# Altium schematic sheet Power.SchDoc(Power)
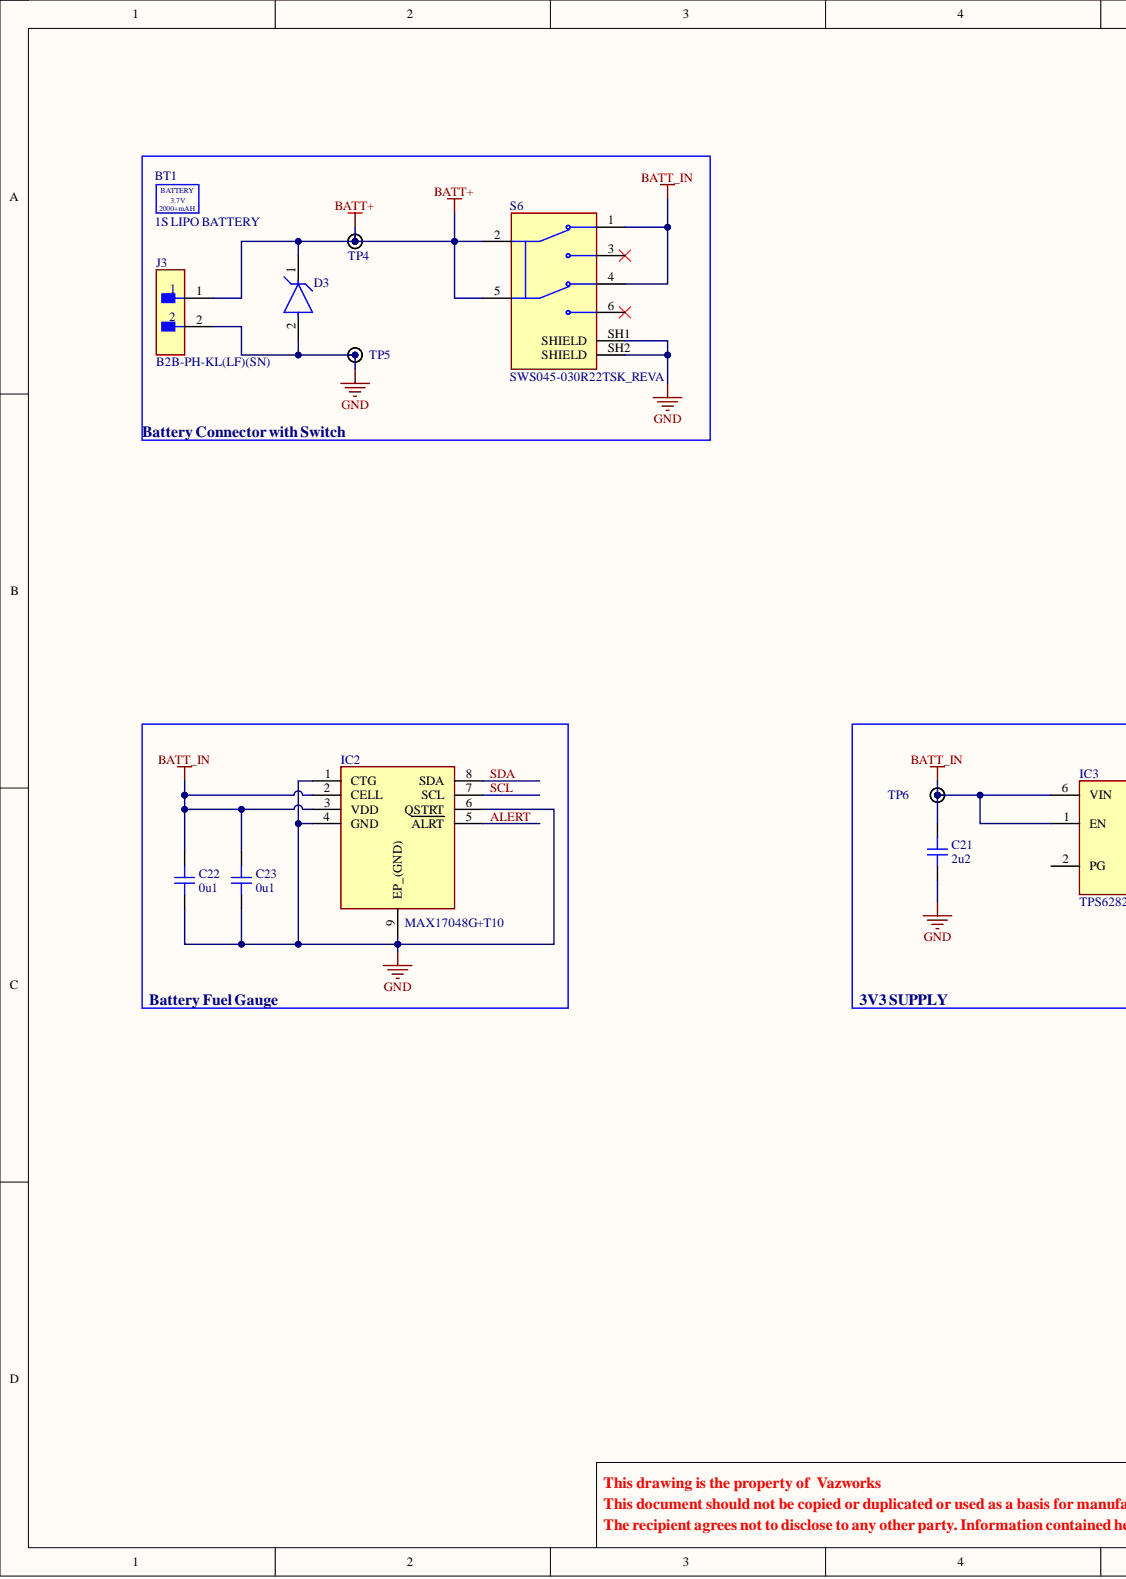
[diagram p0: Power.SchDoc, left]
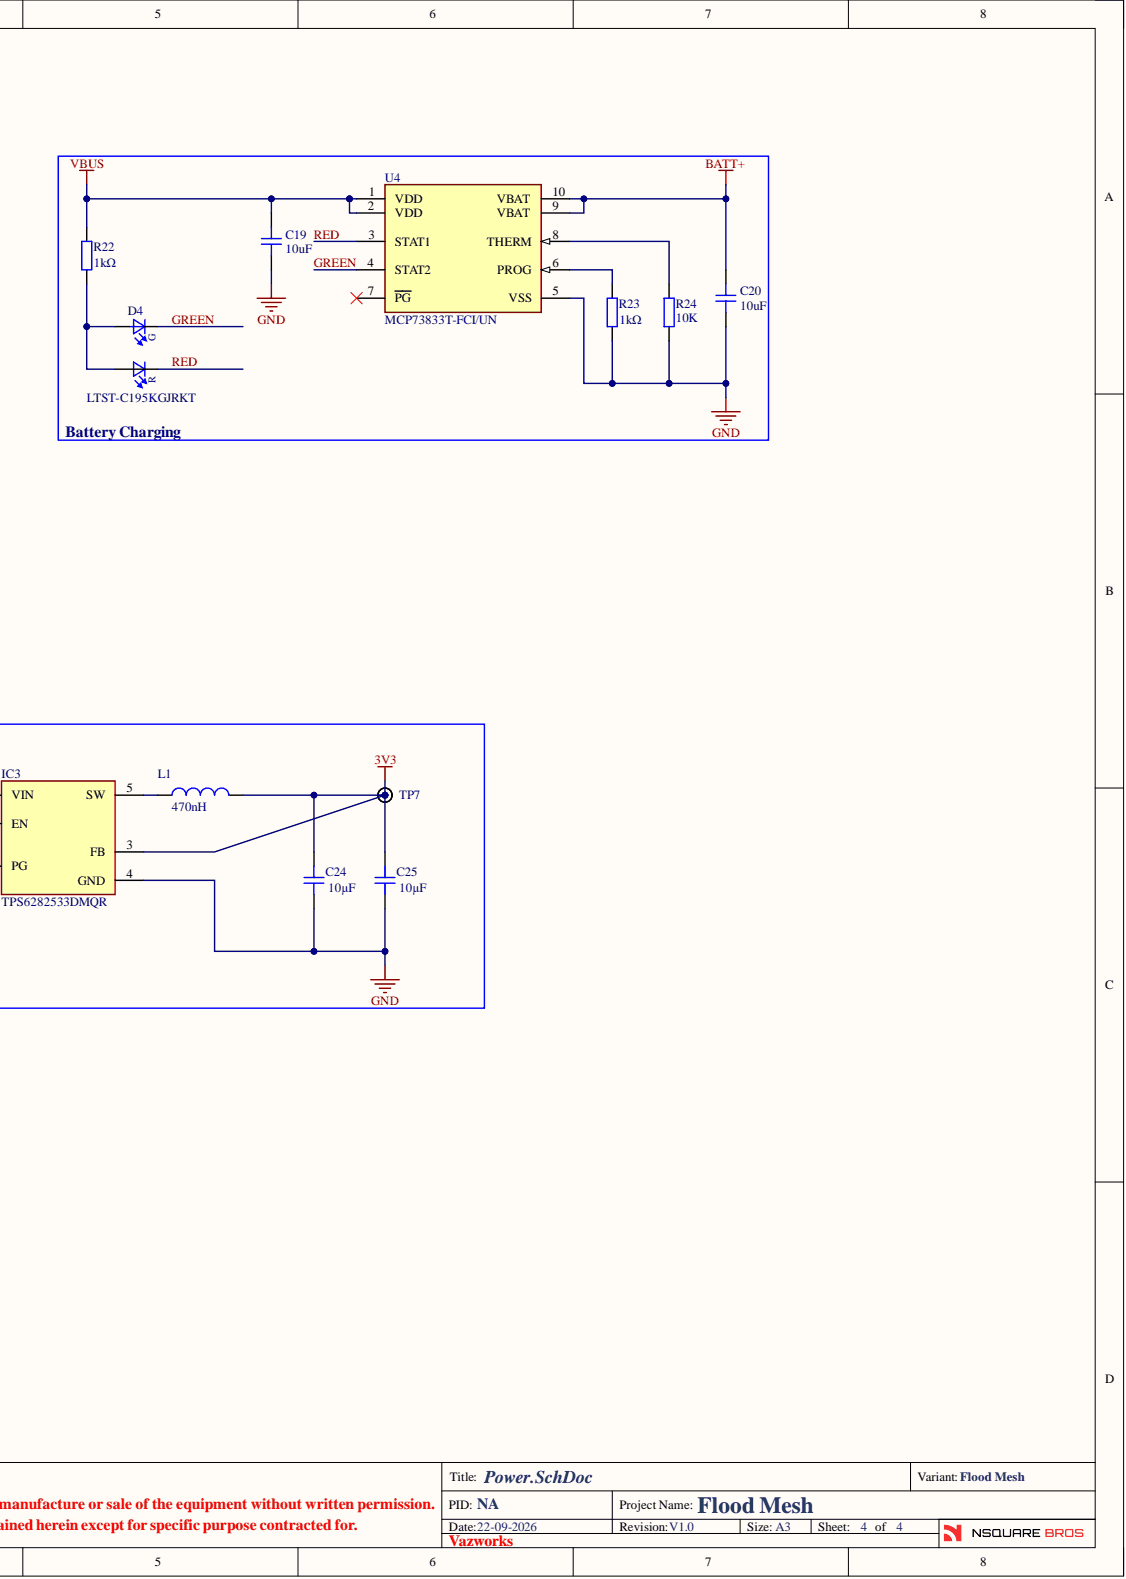
[diagram p1: Power.SchDoc, right]

## Parts
BT1  1S LIPO BATTERY  (mechanical)
C19  value 10uF  [CAP_0603]  (hidden comment: GRM188R60J106ME47D)
C20  value 10uF  [CAP_0603]  (hidden comment: GRM188R60J106ME47D)
C21  value 2u2  [CAP_0603]  (hidden comment: GRM188Z71C225KE43J)
C22  value 0u1  [CAP_0402]  (hidden comment: CC0402JRX7R8BB104)
C23  value 0u1  [CAP_0402]  (hidden comment: CC0402JRX7R8BB104)
C24  value 10µF  [CAP_0603]  (hidden comment: CL10A106KP8NNNC)
C25  value 10µF  [CAP_0603]  (hidden comment: CL10A106KP8NNNC)
D3  ESDA7P60-1U1M  [FP-1610-MFG]  (value not drawn)
D4  LTST-C195KGJRKT  [LTST-BILED-15X16]
IC2  MAX17048G+T10  [SON50P200X200X80-9N-D]
IC3  TPS6282533DMQR  [TPS6282533DMQR]
J3  B2B-PH-KL(LF)(SN)  [FP-B2B-PH-KL_LF_SN-MFG]
L1  Inductance: 470nH  [WE-PMCI_252012]  (hidden comment: 74479288147)
R22  value 1kΩ  [RES_0603]  (hidden comment: AC0603FR-7W1KL)
R23  value 1kΩ  [RES_0603]  (hidden comment: AC0603FR-7W1KL)
R24  value 10K  [RES_0402]  (hidden comment: AC0402FR-7W10KL)
S6  SWS045-030R22TSK_REVA  [SW_SWS045-030R22TSK_REVA]
TP4  TP_NOBOM  [PAD_RND_1MM]  (value not drawn)  (no BOM)
TP5  TP_NOBOM  [PAD_RND_1MM]  (value not drawn)  (no BOM)
TP6  TP_NOBOM  [PAD_RND_1MM]  (value not drawn)  (no BOM)
TP7  TP_NOBOM  [PAD_RND_1MM]  (value not drawn)  (no BOM)
U4  MCP73833T-FCI/UN  [FP-C04-021-IPC_B]

## Nets
3V3: C24.2 C25.2 IC3.3(FB) L1.2 TP7.1
BATT+: C20.2 D3.1 J3.1 S6.2 S6.5 TP4.1 U4.9(VBAT) U4.10(VBAT)
BATT_IN: C21.2 C22.2 C23.2 IC2.2(CELL) IC2.3(VDD) IC3.1(EN) IC3.6(VIN) S6.1 S6.4 TP6.1
GND: C19.1 C20.1 C21.1 C22.1 C23.1 C24.1 C25.1 D3.2 IC2.1(CTG) IC2.4(GND) IC2.6(QSTRT) IC2.9(EP_(GND)) IC3.4(GND) J3.2 R23.1 R24.1 S6.SH1(SHIELD) S6.SH2(SHIELD) TP5.1 U4.5(VSS)
VBUS: C19.2 R22.2 U4.1(VDD) U4.2(VDD)
ALERT: IC2.5(ALRT)
GREEN: D4.P$3 U4.4(STAT2)
RED: D4.P$4 U4.3(STAT1)
SCL: IC2.7(SCL)
SDA: IC2.8(SDA)
NetD4_P$1: D4.P$1 D4.P$2 R22.1
NetIC3_5: IC3.5(SW) L1.1
NetR23_2: R23.2 U4.6(PROG)
NetR24_2: R24.2 U4.8(THERM)

Not connected: IC3 2(PG); S6 3 6; U4 7(PG)

## Library data of the parts
BT1 = Battery: Library Name: Battery.SchLib
C19 C20 = CAP_0603: Ceramic Capacitor, Multilayer, Ceramic, 6.3V, 20% +Tol, 20% -Tol, X5R, 15% TC, 10uF, Surface Mount, 0603; Multilayer: Yes; Capacitor Type: Ceramic; Case/Package: 0603; Category: Ceramic Capacitors; Component Kind: Standard; ComponentLink1Description: https://datasheet.ciiva.com:443/pdfs/VipMasterIC/IC/MURE/MURE-S-A0008911298/MURE-S-A0008911290-1.pdf?src-supplier=IHS+Markit; ComponentLink1URL: https://datasheet.ciiva.com:443/pdfs/VipMasterIC/IC/MURE/MURE-S-A0008911298/MURE-S-A0008911290-1.pdf?src-supplier=IHS+Markit; ComponentLink2Description: https://datasheet.ciiva.com/28584/415295-28584232.pdf?src-supplier=Element14; ComponentLink2URL: https://datasheet.ciiva.com/28584/415295-28584232.pdf?src-supplier=Element14; ComponentLink3Description: https://datasheet.ciiva.com/3777/10175grm188r60j106me4723-3777268.pdf?src-supplier=Arrow+Electronics; ComponentLink3URL: https://datasheet.ciiva.com/3777/10175grm188r60j106me4723-3777268.pdf?src-supplier=Arrow+Electronics; ComponentLink4Description: https://datasheet.ciiva.com/22776/1747457-22776418.pdf?src-supplier=Farnell; ComponentLink4URL: https://datasheet.ciiva.com/22776/1747457-22776418.pdf?src-supplier=Farnell; ComponentLink5Description: https://datasheet.ciiva.com/29202/450781-da-01-de-vs-chip-kond-0603-10-0-uf-20pr-6-3v-x5r-29202806.pdf?src-supplier=Conrad; ComponentLink5URL: https://datasheet.ciiva.com/29202/450781-da-01-de-vs-chip-kond-0603-10-0-uf-20pr-6-3v-x5r-29202806.pdf?src-supplier=Conrad; ComponentLink6Description: https://datasheet.ciiva.com/29199/454401-da-01-en-vs-kondensator-0603-29199677.pdf?src-supplier=Conrad; ComponentLink6URL: https://datasheet.ciiva.com/29199/454401-da-01-en-vs-kondensator-0603-29199677.pdf?src-supplier=Conrad; ComponentLink7Description: https://datasheet.ciiva.com/138/partnumbering-e-01-138659.pdf?src-supplier=Digikey; ComponentLink7URL: https://datasheet.ciiva.com/138/partnumbering-e-01-138659.pdf?src-supplier=Digikey; ComponentLink8Description: https://datasheet.ciiva.com/138/partnumbering-e-01-138658.pdf?src-supplier=Digikey; ComponentLink8URL: https://datasheet.ciiva.com/138/partnumbering-e-01-138658.pdf?src-supplier=Digikey; ComponentLink9Description: https://datasheet.ciiva.com/16373/l0005e-16373580.pdf?src-supplier=Future+Electronics; ComponentLink9URL: https://datasheet.ciiva.com/16373/l0005e-16373580.pdf?src-supplier=Future+Electronics; ComponentLink10Description: https://datasheet.ciiva.com/14116/grmseries-highdielectrictype-eng-14116428.pdf?src-supplier=Distrelec; ComponentLink10URL: https://datasheet.ciiva.com/14116/grmseries-highdielectrictype-eng-14116428.pdf?src-supplier=Distrelec; ComponentLink11Description: https://datasheet.ciiva.com/138/partnumbering-e-01-138660.pdf?src-supplier=Digikey; ComponentLink11URL: https://datasheet.ciiva.com/138/partnumbering-e-01-138660.pdf?src-supplier=Digikey; Dielectric Material: Ceramic; Height: 0.8mm; JESD-609 Code: e3; Length: 1.6mm; Max Operating Temperature: 85°C; Min Operating Temperature: -55°C; Mounting Technology: Surface Mount; Package Shape: Rectangular; Package Style: SMTMeter; Packaging: Tape and Reel; Pins: 2; Pricing: 1=0.14, 10=0.067, 50=0.067, 100=0.041, 1000=0.03, 10000=0.023 (USD); Rated DC Voltage (URdc): 6.3V; Reach SVHC Compliant: Yes; RoHS: Yes; RoHS Compliant: Yes; Stock: 161800; Supplier: Mouser; Supplier Part Number: 81-GRM188R60J106ME47; Temperature Characteristics Code: X5R; Terminal Finish: Nickel; Termination: Wraparound; Tolerance  (fill in as +-): 20%; Tolerance (fill in as +-): 20%; Width: 0.8mm; Library Name: Schematic-Lib-PhilsLab.SchLib
C21 = CAP_0603: Cap, Mlcc, 2.2Uf, X7R, 16V, 0603 Rohs Compliant: Yes |Murata GRM188Z71C225KE43J; Manufacturer Lifecycle Status: IN PRODUCTION; Dielectric Material: Ceramic; Tolerance: 10%; Case/Package: 0603; Category: Ceramic Capacitors; Component Kind: Standard; ComponentLink1Description: https://datasheet.octopart.com:443/GRM188Z71C225KE43J-Murata-datasheet-141276012.pdf?src-supplier=Geoflip; ComponentLink1URL: https://datasheet.octopart.com:443/GRM188Z71C225KE43J-Murata-datasheet-141276012.pdf?src-supplier=Geoflip; ComponentLink2Description: https://datasheet.octopart.com:443/GRM188Z71C225KE43J-Murata-datasheet-165579666.pdf?src-supplier=TME; ComponentLink2URL: https://datasheet.octopart.com:443/GRM188Z71C225KE43J-Murata-datasheet-165579666.pdf?src-supplier=TME; ComponentLink3Description: https://datasheet.octopart.com:443/GRM188Z71C225KE43J-Murata-datasheet-140705915.pdf?src-supplier=Ciiva; ComponentLink3URL: https://datasheet.octopart.com:443/GRM188Z71C225KE43J-Murata-datasheet-140705915.pdf?src-supplier=Ciiva; ComponentLink4Description: https://datasheet.octopart.com:443/GRM188Z71C225KE43J-Murata-datasheet-138743433.pdf?src-supplier=Ciiva; ComponentLink4URL: https://datasheet.octopart.com:443/GRM188Z71C225KE43J-Murata-datasheet-138743433.pdf?src-supplier=Ciiva; ComponentLink5Description: http://sigma.octopart.com:80/reach_statement/Murata-company-16.pdf?src-supplier=Compliance+Statements; ComponentLink5URL: http://sigma.octopart.com:80/reach_statement/Murata-company-16.pdf?src-supplier=Compliance+Statements; Export Control Classification Number (ECCN) Code: EAR99; Harmonized Tariff Schedule (HTS) Code: 8532.24.00.20; Height: 800µm; Introduction Date: 2017-02-21; Length: 1.6mm; Lifecycle Status: Production; Material: Ceramic; Max Operating Temperature: 125°C; Min Operating Temperature: -55°C; Mounting Technology: Surface Mount; Number of Terminals: 2; Pricing: 1=0.16, 10=0.092, 50=0.065, 100=0.0568, 500=0.04284, 1000=0.03855, 2500=0.03404, 5000=0.03132 (USD); REACH SVHC: Yes; RoHS: Compliant; Stock: 4516; Supplier: DigiKey; Supplier Part Number: 490-GRM188Z71C225KE43JCT-ND; Thickness: 800µm; Voltage Rating: 16V; Voltage Rating (DC): 16V; Width: 800µm; Library Name: Schematic-Lib-PhilsLab.SchLib
C22 C23 = CAP_0402: 25V 100nF X7R ±5% 0402 Multilayer Ceramic Capacitors MLCC - SMD/SMT ROHS; Case/Package: 0402; Category: Ceramic Capacitors; China RoHS: Compliant; Component Kind: Standard; ComponentLink1Description: https://datasheet.octopart.com:443/CC0402JRX7R8BB104-Yageo-datasheet-177165144.pdf?src-supplier=IHS; ComponentLink1URL: https://datasheet.octopart.com:443/CC0402JRX7R8BB104-Yageo-datasheet-177165144.pdf?src-supplier=IHS; ComponentLink2Description: https://datasheet.octopart.com:443/CC0402JRX7R8BB104-Yageo-datasheet-166511530.pdf?src-supplier=Future+Electronics; ComponentLink2URL: https://datasheet.octopart.com:443/CC0402JRX7R8BB104-Yageo-datasheet-166511530.pdf?src-supplier=Future+Electronics; ComponentLink3Description: https://datasheet.octopart.com:443/CC0402JRX7R8BB104-Yageo-datasheet-137700082.pdf?src-supplier=Future+Electronics; ComponentLink3URL: https://datasheet.octopart.com:443/CC0402JRX7R8BB104-Yageo-datasheet-137700082.pdf?src-supplier=Future+Electronics; ComponentLink4Description: https://datasheet.octopart.com:443/CC0402JRX7R8BB104-Yageo-Group-datasheet-165992755.pdf?src-supplier=ODG+(Origin+Data+Global); ComponentLink4URL: https://datasheet.octopart.com:443/CC0402JRX7R8BB104-Yageo-Group-datasheet-165992755.pdf?src-supplier=ODG+(Origin+Data+Global); ComponentLink5Description: https://datasheet.octopart.com:443/CC0402JRX7R8BB104-Yageo-datasheet-151948686.pdf?src-supplier=Farnell; ComponentLink5URL: https://datasheet.octopart.com:443/CC0402JRX7R8BB104-Yageo-datasheet-151948686.pdf?src-supplier=Farnell; ComponentLink6Description: https://datasheet.octopart.com/CC0402JRX7R8BB104-Yageo-datasheet-10883670.pdf; ComponentLink6URL: https://datasheet.octopart.com/CC0402JRX7R8BB104-Yageo-datasheet-10883670.pdf; ComponentLink7Description: http://sigma.octopart.com:80/conflict_mineral_statement/Yageo-company-3.pdf?src-supplier=Compliance+Statements; ComponentLink7URL: http://sigma.octopart.com:80/conflict_mineral_statement/Yageo-company-3.pdf?src-supplier=Compliance+Statements; ComponentLink8Description: http://sigma.octopart.com:80/rohs_statement/Yageo-company-2.pdf?src-supplier=Compliance+Statements; ComponentLink8URL: http://sigma.octopart.com:80/rohs_statement/Yageo-company-2.pdf?src-supplier=Compliance+Statements; Country of Origin: Mainland China, Taiwan; Dielectric Material: Ceramic; Export Control Classification Number (ECCN) Code: EAR99; Halogen Free: Halogen Free; Harmonized Tariff Schedule (HTS) Code: 8532.24.00.20; Height: 500µm; Introduction Date: 2013-05-01; Lead Free: Lead Free; Length: 1mm; Lifecycle Status: Production; Max Operating Temperature: 125°C; Min Operating Temperature: -55°C; Mounting Technology: Surface Mount; Number of Terminals: 2; Pricing: 10000=0.0095, 20000=0.0086 (USD); REACH SVHC: Yes; RoHS: Compliant; Stock: 1050000; Supplier: Arrow Electronics; Supplier Part Number: CC0402JRX7R8BB104; Tolerance: 5%; Voltage Rating (DC): 25V; Width: 500µm; Library Name: Schematic-Lib-PhilsLab.SchLib
C24 C25 = CAP_0603: 10V 10uF X5R ±10% 0603 Multilayer Ceramic Capacitors MLCC - SMD/SMT ROHS; Case Code (Imperial): 0603; Case Code (Metric): 1608; Case/Package: 0603; Category: Ceramic Capacitors; China RoHS: Compliant; Component Kind: Standard; ComponentLink1Description: https://datasheet.octopart.com:443/CL10A106KP8NNNC-Samsung-Electro-Mechanics-datasheet-181960519.pdf?src-supplier=Newark; ComponentLink1URL: https://datasheet.octopart.com:443/CL10A106KP8NNNC-Samsung-Electro-Mechanics-datasheet-181960519.pdf?src-supplier=Newark; ComponentLink2Description: https://datasheet.octopart.com/CL10A106KP8NNNC-Samsung-Electro-Mechanics-datasheet-8324245.pdf; ComponentLink2URL: https://datasheet.octopart.com/CL10A106KP8NNNC-Samsung-Electro-Mechanics-datasheet-8324245.pdf; ComponentLink3Description: https://datasheet.octopart.com/CL10A106KP8NNNC-Samsung-Electro-Mechanics-datasheet-97040617.pdf; ComponentLink3URL: https://datasheet.octopart.com/CL10A106KP8NNNC-Samsung-Electro-Mechanics-datasheet-97040617.pdf; ComponentLink4Description: https://datasheet.octopart.com:443/CL10A106KP8NNNC-Samsung-Electro-Mechanics-datasheet-136031754.pdf?src-supplier=Future+Electronics; ComponentLink4URL: https://datasheet.octopart.com:443/CL10A106KP8NNNC-Samsung-Electro-Mechanics-datasheet-136031754.pdf?src-supplier=Future+Electronics; ComponentLink5Description: https://datasheet.octopart.com:443/CL10A106KP8NNNC-Samsung-Electro-Mechanics-datasheet-110226289.pdf?src-supplier=Future+Electronics; ComponentLink5URL: https://datasheet.octopart.com:443/CL10A106KP8NNNC-Samsung-Electro-Mechanics-datasheet-110226289.pdf?src-supplier=Future+Electronics; ComponentLink6Description: https://datasheet.octopart.com:443/CL10A106KP8NNNC-Samsung-Electro-Mechanics-datasheet-81461787.pdf?src-supplier=Future+Electronics; ComponentLink6URL: https://datasheet.octopart.com:443/CL10A106KP8NNNC-Samsung-Electro-Mechanics-datasheet-81461787.pdf?src-supplier=Future+Electronics; ComponentLink7Description: https://datasheet.octopart.com:443/CL10A106KP8NNNC-Samsung-datasheet-48647232.pdf?src-supplier=Future+Electronics; ComponentLink7URL: https://datasheet.octopart.com:443/CL10A106KP8NNNC-Samsung-datasheet-48647232.pdf?src-supplier=Future+Electronics; ComponentLink8Description: https://datasheet.octopart.com:443/CL10A106KP8NNNC-Samsung-datasheet-34157262.pdf?src-supplier=Future+Electronics; ComponentLink8URL: https://datasheet.octopart.com:443/CL10A106KP8NNNC-Samsung-datasheet-34157262.pdf?src-supplier=Future+Electronics; ComponentLink9Description: https://datasheet.octopart.com:443/CL10A106KP8NNNC-Samsung-datasheet-26589120.pdf?src-supplier=Future+Electronics; ComponentLink9URL: https://datasheet.octopart.com:443/CL10A106KP8NNNC-Samsung-datasheet-26589120.pdf?src-supplier=Future+Electronics; ComponentLink10Description: https://datasheet.octopart.com:443/CL10A106KP8NNNC-Samsung-Electro-Mechanics-datasheet-149156481.pdf?src-supplier=Future+Electronics; ComponentLink10URL: https://datasheet.octopart.com:443/CL10A106KP8NNNC-Samsung-Electro-Mechanics-datasheet-149156481.pdf?src-supplier=Future+Electronics; ComponentLink11Description: https://datasheet.octopart.com:443/CL10A106KP8NNNC-Samsung-Electro-Mechanics-datasheet-11968064.pdf?src-supplier=Arrow+Electronics; ComponentLink11URL: https://datasheet.octopart.com:443/CL10A106KP8NNNC-Samsung-Electro-Mechanics-datasheet-11968064.pdf?src-supplier=Arrow+Electronics; ComponentLink12Description: https://datasheet.octopart.com:443/CL10A106KP8NNNC-Samsung-datasheet-8326407.pdf?src-supplier=TME; ComponentLink12URL: https://datasheet.octopart.com:443/CL10A106KP8NNNC-Samsung-datasheet-8326407.pdf?src-supplier=TME; Contact Plating: Tin; Country of Origin: Mainland China, Philippines, South Korea; Depth: 800µm; Dielectric Material: Ceramic; Export Control Classification Number (ECCN) Code: EAR99; Harmonized Tariff Schedule (HTS) Code: 8532.24.00.20; Height: 800µm; Introduction Date: 2009-10-27; Lead Free: Lead Free; Length: 1.6mm; Lifecycle Status: Production; Material: Ceramic; Max Operating Temperature: 85°C; Min Operating Temperature: -55°C; Mounting Technology: Surface Mount; Number of Terminals: 2; Pricing: 4000=0.01797, 8000=0.01761, 16000=0.01726, 32000=0.01693, 64000=0.01661 (USD); Radiation Hardening: No; REACH SVHC: Yes; RoHS: Compliant; Schedule B: 8532240020, 8532240020|8532240020|8532240020|8532240020|8532240020|8532240020|8532240020|8532240020|8532240020|8532240020|8532240020|8532240020|8532240020|8532240020|8532240020|8532240020|8532240020|8532240020|8532240020|8532240020|8532240020|8532240020|8532240020|85; Stock: 896000; Supplier: Avnet; Supplier Part Number: CL10A106KP8NNNC; Thickness: 889µm; Tolerance: 10%; Voltage Rating: 10V; Voltage Rating (DC): 10V; Width: 800µm; Library Name: Schematic-Lib-PhilsLab.SchLib
D3 = CMP-12225-000013-1: TVS DIODE 5V 11.6V 1610; Manufacturer: STMicroelectronics; Breakdown Voltage: 6.4V; Clamping Voltage: 10.2V; Direction: Unidirectional; Max Junction Temp: +150°C; Number Of Channels: 1; Peak Pulse Current: 60A; Peak Pulse Power: 700W; Standoff Height: 0mm; Automotive: No; Category: Diode; Component Kind: Standard; ComponentLink2Description: Datasheet; ComponentLink2URL: http://www.st.com/content/ccc/resource/technical/document/datasheet/44/e9/26/44/42/ee/4f/e5/DM00139972.pdf/files/DM00139972.pdf/jcr:content/translations/en.DM00139972.pdf; Device Class L1: Circuit Protection; Device Class L2: TVS Diodes; Device Class L3: unset; Digikey Description: TVS DIODE 5V 11.6V 1610; Height: 0.65mm; Lead Free: Yes; Library Name: Altium Content Vault; Manufacturer Part Number: ESDA7P60-1U1M; Octopart: https://octopart.com/esda7p60-1u1m-stmicroelectronics-52112702; Package: 1610; Reverse Standoff Voltage: 5V; RoHS: TRUE; Temperature: -55°C to +150°C; Temperature Range High: +150°C; Temperature Range Low: -55°C
D4 = LTST-C195KGJRKT: MF: {'manufacturer': u'Lite-On Inc.'}; Availability: Unavailable; Component Kind: Standard; MP: LTST-C195KGJRKT; Package: None; Price: None
IC2 = MAX17048G+T10: Integrated Circuit; Manufacturer_Name: Maxim Integrated; Arrow Part Number: MAX17048G+T10; Arrow Price/Stock: https://www.arrow.com/en/products/max17048gt10/maxim-integrated?region=nac; Component Kind: Standard; Datasheet Link: https://datasheets.maximintegrated.com/en/ds/MAX17048-MAX17049.pdf; Height: 0.8mm; Manufacturer_Part_Number: MAX17048G+T10; Mouser Part Number: 700-MAX17048G+T10; Mouser Price/Stock: https://www.mouser.co.uk/ProductDetail/Maxim-Integrated/MAX17048G%2bT10?qs=D7PJwyCwLAoGnnn8jEPRBQ%3D%3D
IC3 = TPS6282533DMQR: Integrated Circuit; Manufacturer_Name: Texas Instruments; Arrow Part Number: TPS6282533DMQR; Arrow Price/Stock: https://www.arrow.com/en/products/tps6282533dmqr/texas-instruments?utm_currency=USD&region=nac; Component Kind: Standard; Datasheet Link: https://www.ti.com/lit/ds/symlink/tps62825a.pdf?ts=1656597904415&ref_url=https%253A%252F%252Fwww.google.com%252F; Height: 1mm; Library Name: SamacSys.SchLib; Manufacturer_Part_Number: TPS6282533DMQR; Mouser Part Number: 595-TPS6282533DMQR; Mouser Price/Stock: https://www.mouser.co.uk/ProductDetail/Texas-Instruments/TPS6282533DMQR?qs=e8oIoAS2J1TctVdod4W0lA%3D%3D
J3 = CMP-17439-000682-1: Connector Header, PH Series, 2 Position, 2.00mm, Shrouded Through Hole, Press-Fit, 100V, 32-24 AWG, Solder, Gray; Number of Positions: 2; Pitch: 2mm; Component Kind: Standard; Connector Type: Header; Contact Finish - Mating: TIN; Contact Material: Brass; Contact Shape: Square; Contact Type: Male Pin; Fastening Type: Detent; Flammability Rating: UL94 V-0; Insulation Color: Grey; Insulation Material: Nylon; Lead Free: Lead Free; Library Name: Altium Content Vault; Mount: Through Hole; Number of Rows: 1; Packaging: Bulk; Series: PH; Termination: Press-Fit; Voltage Rating: 100V
L1 = CMP-03913-71101460-2: Fixed Ind 470NH 3.1A 34 Mohm SMD; Current Rating: 2.3A; DC Resistance (DCR): 18mΩ; Inductance Tolerance: 20%; Saturation Current: 3.75A; Self Resonant Frequency: 100MHz; Shielding: Shielded; Test Frequency: 1MHz; Ambient Temperature Range High: 85°C; Ambient Temperature Range Low: -40°C; Case Code (Imperial): 1008; Case Code (Metric): 2520; Case/Package: 1008; Component Kind: Standard; Height: 1.2mm; Height - Seated (Max): 990.6µm; Length: 2.5mm; Library Name: Altium Content Vault; Max Operating Temperature: 125°C; Min Operating Temperature: -40°C; Mount: Surface Mount; Number of Pins: 2; REACH SVHC: Unknown; RMS Current (Irms): 3.1A; RoHS: Compliant; Tolerance: 20%; Width: 2mm
R22 R23 = RES_0603: Res Thick Film 0603 1K Ohm 1% 0.2W(1/5W) ±100ppm/C Pad SMD T/R Automotive AEC-Q200; Power Rating: 200mW; Temperature Coefficient: 100ppm/°C; Voltage Rating: 75V; Case/Package: 0603; Category: Chip SMD Resistors; China RoHS: Non-Compliant; Component Kind: Standard; ComponentLink1Description: https://datasheet.octopart.com:443/AC0603FR-7W1KL-Yageo-datasheet-182189377.pdf?src-supplier=IHS; ComponentLink1URL: https://datasheet.octopart.com:443/AC0603FR-7W1KL-Yageo-datasheet-182189377.pdf?src-supplier=IHS; ComponentLink2Description: https://datasheet.octopart.com:443/AC0603FR-7W1KL-Yageo-datasheet-86786639.pdf?src-supplier=Upverter; ComponentLink2URL: https://datasheet.octopart.com:443/AC0603FR-7W1KL-Yageo-datasheet-86786639.pdf?src-supplier=Upverter; ComponentLink3Description: https://datasheet.octopart.com:443/AC0603FR-7W1KL-Yageo-datasheet-178509465.pdf?src-supplier=Newark; ComponentLink3URL: https://datasheet.octopart.com:443/AC0603FR-7W1KL-Yageo-datasheet-178509465.pdf?src-supplier=Newark; ComponentLink4Description: https://datasheet.octopart.com:443/AC0603FR-7W1KL-Yageo-datasheet-182877978.pdf?src-supplier=Newark; ComponentLink4URL: https://datasheet.octopart.com:443/AC0603FR-7W1KL-Yageo-datasheet-182877978.pdf?src-supplier=Newark; ComponentLink5Description: https://datasheet.octopart.com:443/AC0603FR-7W1KL-Yageo-Group-datasheet-188689268.pdf?src-supplier=LCSC; ComponentLink5URL: https://datasheet.octopart.com:443/AC0603FR-7W1KL-Yageo-Group-datasheet-188689268.pdf?src-supplier=LCSC; ComponentLink6Description: https://datasheet.octopart.com/AC0603FR-7W1KL-Yageo-datasheet-12512238.pdf; ComponentLink6URL: https://datasheet.octopart.com/AC0603FR-7W1KL-Yageo-datasheet-12512238.pdf; ComponentLink7Description: http://sigma.octopart.com:80/conflict_mineral_statement/Yageo-company-3.pdf?src-supplier=Compliance+Statements; ComponentLink7URL: http://sigma.octopart.com:80/conflict_mineral_statement/Yageo-company-3.pdf?src-supplier=Compliance+Statements; ComponentLink8Description: http://sigma.octopart.com:80/rohs_statement/Yageo-company-2.pdf?src-supplier=Compliance+Statements; ComponentLink8URL: http://sigma.octopart.com:80/rohs_statement/Yageo-company-2.pdf?src-supplier=Compliance+Statements; Composition: Thick Film; Country of Origin: Mainland China, Taiwan; Export Control Classification Number (ECCN) Code: EAR99; Harmonized Tariff Schedule (HTS) Code: 8533.21.00.30; Height: 450µm; Introduction Date: 2017-06-20; Length: 1.6mm; Lifecycle Status: Production; Max Operating Temperature: 155°C; Min Operating Temperature: -55°C; Mounting Technology: Surface Mount; Number of Terminals: 2; Packaging: Tape & Reel; Pricing: 5000=0.0052, 10000=0.0042 (USD); Ratings: AEC-Q200; REACH SVHC: Yes; RoHS: Compliant; Stock: 25000; Supplier: Arrow Electronics; Supplier Part Number: AC0603FR-7W1KL; Tolerance: 1%; Width: 800µm; Working Voltage: 75V; Library Name: Schematic-Lib-PhilsLab.SchLib
R24 = RES_0402: Res Thick Film 0402 10K Ohm 1% 0.125W(1/8W) ±100ppm/C Pad SMD Automotive T/R; Power Dissipation: 125mW; Temperature Coefficient: 100ppm/°C; Voltage Rating: 50V; Working Voltage: 75V; Case/Package: 0402; Category: Chip SMD Resistors; China RoHS: Non-Compliant; Component Kind: Standard; ComponentLink1Description: https://datasheet.octopart.com:443/AC0402FR-7W10KL-Yageo-datasheet-182189377.pdf?src-supplier=IHS; ComponentLink1URL: https://datasheet.octopart.com:443/AC0402FR-7W10KL-Yageo-datasheet-182189377.pdf?src-supplier=IHS; ComponentLink2Description: https://datasheet.octopart.com:443/AC0402FR-7W10KL-Yageo-datasheet-83209224.pdf?src-supplier=Upverter; ComponentLink2URL: https://datasheet.octopart.com:443/AC0402FR-7W10KL-Yageo-datasheet-83209224.pdf?src-supplier=Upverter; ComponentLink3Description: https://datasheet.octopart.com:443/AC0402FR-7W10KL-Yageo-datasheet-178509465.pdf?src-supplier=Farnell; ComponentLink3URL: https://datasheet.octopart.com:443/AC0402FR-7W10KL-Yageo-datasheet-178509465.pdf?src-supplier=Farnell; ComponentLink4Description: https://datasheet.octopart.com:443/AC0402FR-7W10KL-Yageo-datasheet-151884373.pdf?src-supplier=Future+Electronics; ComponentLink4URL: https://datasheet.octopart.com:443/AC0402FR-7W10KL-Yageo-datasheet-151884373.pdf?src-supplier=Future+Electronics; ComponentLink5Description: https://datasheet.octopart.com:443/AC0402FR-7W10KL-Yageo-Group-datasheet-189830439.pdf?src-supplier=Future+Electronics; ComponentLink5URL: https://datasheet.octopart.com:443/AC0402FR-7W10KL-Yageo-Group-datasheet-189830439.pdf?src-supplier=Future+Electronics; ComponentLink6Description: https://datasheet.octopart.com:443/AC0402FR-7W10KL-Yageo-datasheet-166720450.pdf?src-supplier=Future+Electronics; ComponentLink6URL: https://datasheet.octopart.com:443/AC0402FR-7W10KL-Yageo-datasheet-166720450.pdf?src-supplier=Future+Electronics; ComponentLink7Description: https://datasheet.octopart.com:443/AC0402FR-7W10KL-Yageo-Group-datasheet-164768995.pdf?src-supplier=ODG+(Origin+Data+Global); ComponentLink7URL: https://datasheet.octopart.com:443/AC0402FR-7W10KL-Yageo-Group-datasheet-164768995.pdf?src-supplier=ODG+(Origin+Data+Global); ComponentLink8Description: https://datasheet.octopart.com:443/AC0402FR-7W10KL-Yageo-Group-datasheet-159083007.pdf?src-supplier=HQonline; ComponentLink8URL: https://datasheet.octopart.com:443/AC0402FR-7W10KL-Yageo-Group-datasheet-159083007.pdf?src-supplier=HQonline; ComponentLink9Description: https://datasheet.octopart.com/AC0402FR-7W10KL-Yageo-datasheet-12512238.pdf; ComponentLink9URL: https://datasheet.octopart.com/AC0402FR-7W10KL-Yageo-datasheet-12512238.pdf; ComponentLink10Description: http://sigma.octopart.com:80/conflict_mineral_statement/Yageo-company-3.pdf?src-supplier=Compliance+Statements; ComponentLink10URL: http://sigma.octopart.com:80/conflict_mineral_statement/Yageo-company-3.pdf?src-supplier=Compliance+Statements; ComponentLink11Description: http://sigma.octopart.com:80/rohs_statement/Yageo-company-2.pdf?src-supplier=Compliance+Statements; ComponentLink11URL: http://sigma.octopart.com:80/rohs_statement/Yageo-company-2.pdf?src-supplier=Compliance+Statements; Composition: Thick Film; Height: 320µm; Lifecycle Status: Production; Max Operating Temperature: 155°C; Min Operating Temperature: -55°C; Mounting Technology: Surface Mount; Number of Terminals: 2; Packaging: Tape & Reel; Power Rating: 125mW; Pricing: 10000=0.002, 20000=0.00192, 40000=0.00186, 80000=0.00179, 160000=0.00173 (USD); REACH SVHC: Yes; RoHS: Compliant; Stock: 3430000; Supplier: Avnet; Supplier Part Number: AC0402FR-7W10KL; Tolerance: 1%; Width: 500µm; Library Name: Schematic-Lib-PhilsLab.SchLib
S6 = SWS045-030R22TSK_REVA: MF: {'manufacturer': u'Global Connector Technology'}; Availability: Unavailable; Component Kind: Standard; MP: SWS045-030R22TSK_REVA; Package: None; Price: None
TP4-TP7 = TEST_POINT_RND_1MM: Test Point Round 1mm (NO BOM); Library Name: Schematic-Lib-PhilsLab.SchLib
U4 = CMP-0133-00203-2: IC LI-ION/LI-POLY CTRLR 10MSOP; Battery Pack Voltage: 4.2V; Fault Protection: Over Temperature; Max Charge Current: 1.1A; Max Supply Voltage: 6V; Min Supply Voltage: 3.75V; Number of Cells: 1; Output Current: 1.2A; Output Voltage: 4.5V; Case/Package: MSOP; Component Kind: Standard; Lead Free: Lead Free; Library Name: Altium Content Vault; Max Operating Temperature: 85°C; Min Operating Temperature: -40°C; Mount: Surface Mount; Number of Pins: 10; Package Quantity: 2500; Packaging: Tape and Reel; Radiation Hardening: No
